# Altium schematic sheet PM.SchDoc(PM)
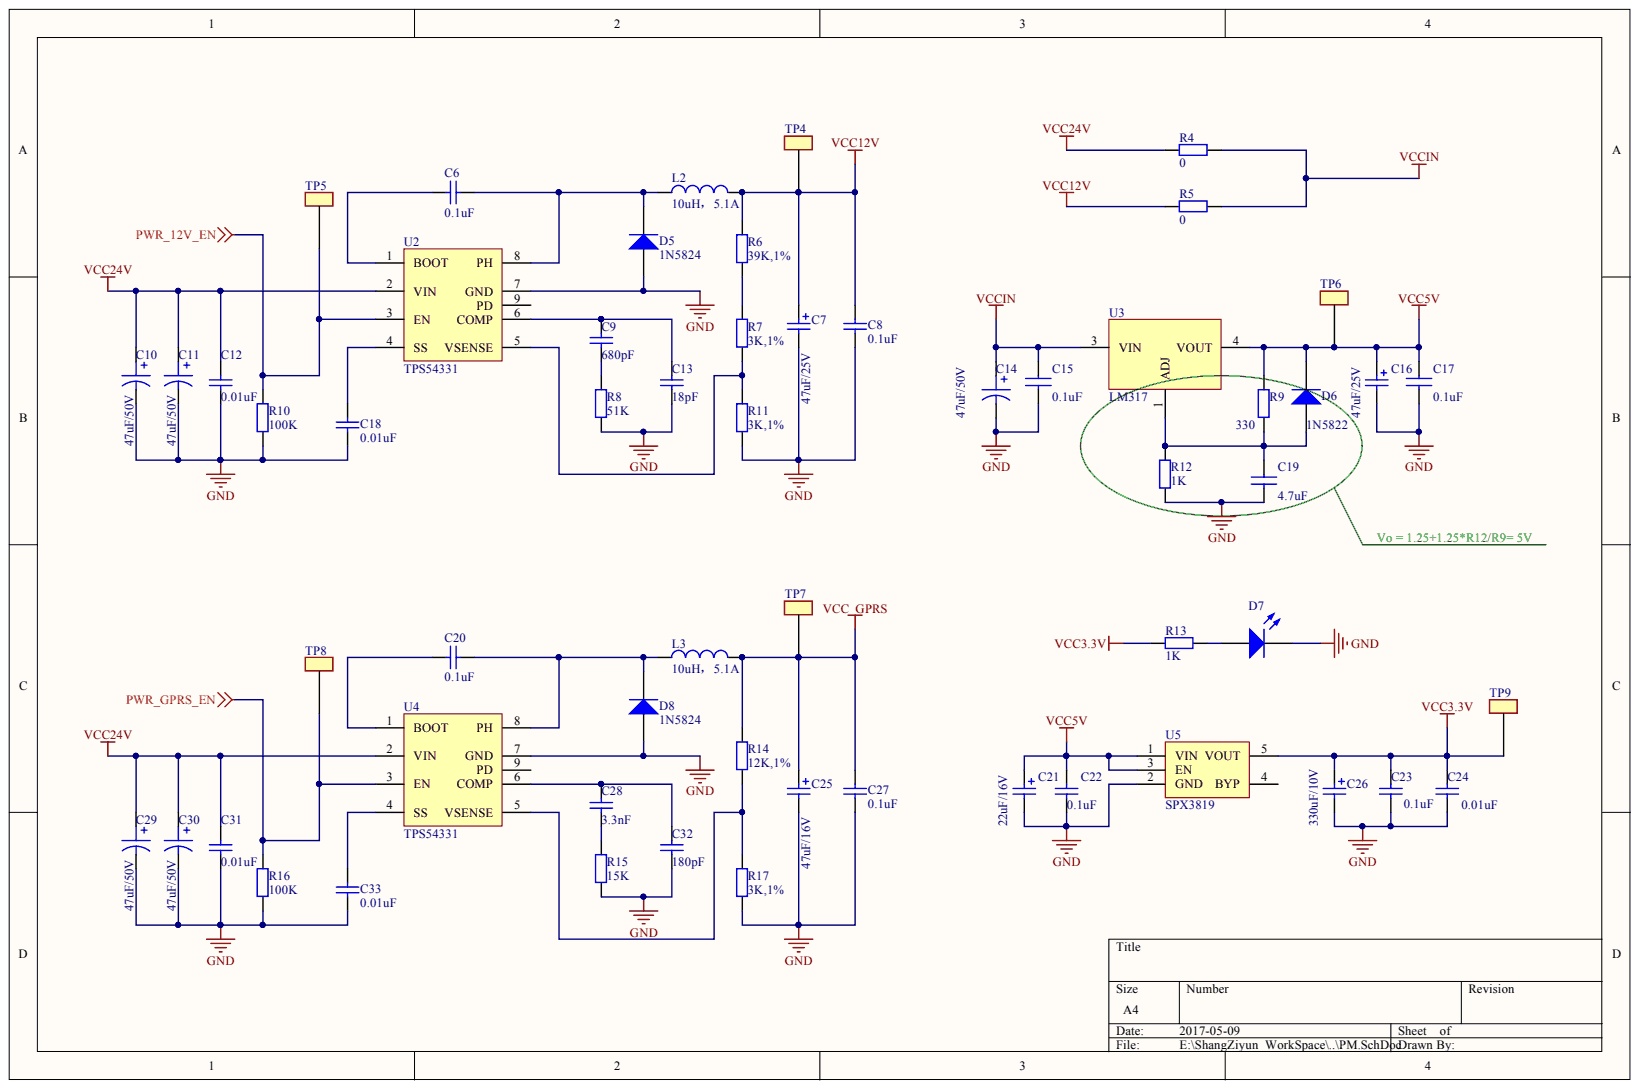
[diagram p0: PM.SchDoc]

## Parts
C6  value 0.1uF  [0603C]  (hidden comment: Capacitor)
C7  47uF/25V  [EIA2816P]
C8  value 0.1uF  [0603C]  (hidden comment: Capacitor)
C9  value 680pF  [0603C]  (hidden comment: Capacitor)
C10  47uF/50V  [1812C]
C11  47uF/50V  [1812C]
C12  value 0.01uF  [0603C]  (hidden comment: Capacitor)
C13  value 18pF  [0603C]  (hidden comment: Capacitor)
C14  47uF/50V  [1812C]
C15  value 0.1uF  [0603C]  (hidden comment: CAP NP)
C16  47uF/25V  [EIA2816P]
C17  value 0.1uF  [0603C]  (hidden comment: CAP NP)
C18  value 0.01uF  [0603C]  (hidden comment: Capacitor)
C19  value 4.7uF  [0603C]  (hidden comment: CAP NP)
C20  value 0.1uF  [0603C]  (hidden comment: Capacitor)
C21  value 22uF/16V  [EIA2816P]
C22  value 0.1uF  [0603C]  (hidden comment: Capacitor)
C23  value 0.1uF  [0603C]  (hidden comment: Capacitor)
C24  value 0.01uF  [0603C]  (hidden comment: Capacitor)
C25  47uF/16V  [EIA2816P]
C26  value 330uF/10V  [EIA2816P]
C27  value 0.1uF  [0603C]  (hidden comment: Capacitor)
C28  value 3.3nF  [0603C]  (hidden comment: Capacitor)
C29  47uF/50V  [1812C]
C30  47uF/50V  [1812C]
C31  value 0.01uF  [0603C]  (hidden comment: Capacitor)
C32  value 180pF  [0603C]  (hidden comment: Capacitor)
C33  value 0.01uF  [0603C]  (hidden comment: Capacitor)
D5  value 1N5824  [DO214AC(SMA)]  (hidden comment: Diode)
D6  1N5822  [DO214AC(SMA)]
D7  LED0  [LED_3mm]  (value not drawn)
D8  value 1N5824  [DO214AC(SMA)]  (hidden comment: Diode)
L2  value 10uH，5.1A  [0630]  (hidden comment: Inductor)
L3  value 10uH，5.1A  [0630]  (hidden comment: Inductor)
R4  value 0  [L1206]  (hidden comment: Resistor)
R5  value 0  [L1206]  (hidden comment: Resistor)
R6  value 39K,1%  [L0603]  (hidden comment: Resistor)
R7  value 3K,1%  [L0603]  (hidden comment: Resistor)
R8  value 51K  [L0603]  (hidden comment: Resistor)
R9  value 330  [L0603]  (hidden comment: Resistor)
R10  value 100K  [L0603]  (hidden comment: Resistor)
R11  value 3K,1%  [L0603]  (hidden comment: Resistor)
R12  value 1K  [L0603]  (hidden comment: Resistor)
R13  value 1K  [L0603]  (hidden comment: Resistor)
R14  value 12K,1%  [L0603]  (hidden comment: Resistor)
R15  value 15K  [L0603]  (hidden comment: Resistor)
R16  value 100K  [L0603]  (hidden comment: Resistor)
R17  value 3K,1%  [L0603]  (hidden comment: Resistor)
TP4  TEST POINT_0  [TP]  (value not drawn)
TP5  TEST POINT_0  [TP]  (value not drawn)
TP6  TEST POINT_0  [TP]  (value not drawn)
TP7  TEST POINT_0  [TP]  (value not drawn)
TP8  TEST POINT_0  [TP]  (value not drawn)
TP9  TEST POINT_0  [TP]  (value not drawn)
U2  TPS54331  [SOP8]
U3  LM317  [DPAK228P]
U4  TPS54331  [SOP8]
U5  SPX3819  [SOT23-5]

## Nets
GND: C7.2 C8.2 C10.2 C11.2 C12.2 C13.1 C14.2 C15.2 C16.2 C17.2 C18.2 C19.2 C21.2 C22.2 C23.2 C24.2 C25.2 C26.2 C27.2 C29.2 C30.2 C31.2 C32.1 C33.2 D5.1 D7.2 D8.1 R8.1 R10.1 R11.1 R12.2 R15.1 R16.1 R17.1 U2.7(GND) U4.7(GND) U5.2(GND)
PWR_12V_EN: R10.2 TP5.1 U2.3(EN)
PWR_GPRS_EN: R16.2 TP8.1 U4.3(EN)
VCC3.3V: C23.1 C24.1 C26.1 R13.2 TP9.1 U5.5(VOUT)
VCC5V: C16.1 C17.1 C21.1 C22.1 D6.2 R9.1 TP6.1 U3.4 U5.1(VIN) U5.3(EN)
VCC12V: C7.1 C8.1 L2.2 R5.2 R6.2 TP4.1
VCC24V: C10.1 C11.1 C12.1 C29.1 C30.1 C31.1 R4.2 U2.2(VIN) U4.2(VIN)
VCC_GPRS: C25.1 C27.1 L3.2 R14.2 TP7.1
VCCIN: C14.1 C15.1 R4.1 R5.1 U3.3
NetC6_1: C6.1 D5.2 L2.1 U2.8(PH)
NetC6_2: C6.2 U2.1(BOOT)
NetC9_1: C9.1 R8.2
NetC9_2: C9.2 C13.2 U2.6(COMP)
NetC18_1: C18.1 U2.4(SS)
NetC19_1: C19.1 D6.1 R9.2 R12.1 U3.1
NetC20_1: C20.1 D8.2 L3.1 U4.8(PH)
NetC20_2: C20.2 U4.1(BOOT)
NetC28_1: C28.1 R15.2
NetC28_2: C28.2 C32.2 U4.6(COMP)
NetC33_1: C33.1 U4.4(SS)
NetD7_1: D7.1 R13.1
NetR6_1: R6.1 R7.2
NetR7_1: R7.1 R11.2 U2.5(VSENSE)
NetR14_1: R14.1 R17.2 U4.5(VSENSE)

Not connected: U2 9(PD); U4 9(PD); U5 4(BYP)

## Library data of the parts
C6 C8 C9 C12 C13 C18 C20 C22-C24 C27 C28 C31-C33 = Cap: Component Kind: Standard; Description: Capacitor; LatestRevisionDate: 17-Jul-2002; LatestRevisionNote: Re-released for DXP Platform.; PackageDescription: Radial Cap, Thru-Hole; 2 Leads; 0.3 in Pin Spacing; PackageReference: RAD-0.3; Published: 8-Jun-2000; Signal Integrity: Cap; Simulation: CAP; Publisher: Altium Limited
  C6 C8 C9 C12 C13 C18 C20 C22 C23 C27 C28 C31-C33: Library Name: Lib1.SCHLIB
  C24: Library Name: Miscellaneous Devices.IntLib
C7 = Cap pol: Value: 330uF/10V钽电容; Component Kind: Standard; Library Name: LNG.SCHLIB
C10 C11 C14 C29 C30 = CAPACITOR POL_3: Value: 330uF/50V铝电解; Component Kind: Standard; Library Name: SENSOR_BOARD_Library.SchLib
C16 = Cap pol: Value: 47uF/25V钽电容; Component Kind: Standard; Library Name: LNG.SCHLIB
C21 C26 = Cap pol: Component Kind: Standard; Library Name: LNG.SCHLIB
C25 = Cap pol: Value: 47uF/16V钽电容; Component Kind: Standard; Library Name: LNG.SCHLIB
D6 = Diode: Component Kind: Standard; Value: 1N5822; Library Name: LNG.SCHLIB
D7 = LED0805: Typical INFRARED GaAs LED; Value: LED_3mm_RED; Component Kind: Standard; LatestRevisionDate: 08-Jul-2005; LatestRevisionNote: Mech Layer 1 removed.; PackageDescription: LED; 2 Leads; PackageReference: LED-0; Published: 8-Jun-2000; Library Name: 简配版生物罐.SCHLIB; Publisher: Altium Limited
L2 L3 = Inductor: Value: 10uH，5.1A; Component Kind: Standard; Library Name: LNG.SCHLIB
R4-R17 = Res: Component Kind: Standard; Library Name: Lib1.SCHLIB
C15 C17 C19 = CAP NP: Component Kind: Standard; Library Name: MAINBOARD_Library.SchLib
D5 D8 = Diode: Component Kind: Standard; Library Name: LNG.SCHLIB
TP4-TP9 = TEST POINT_0: Component Kind: Standard; Library Name: MAINBOARD_Library.SchLib
U2 U4 = TPS54331: Component Kind: Standard; Value: TPS54331; Library Name: Bio.SchLib
U3 = LM317: Component Kind: Standard; Value: LM317; Library Name: Bio.SchLib
U5 = SPX3819: Component Kind: Standard; Value: SPX3819; Library Name: zhileng.SCHLIB
